# Altium schematic sheet Reset.SchDoc
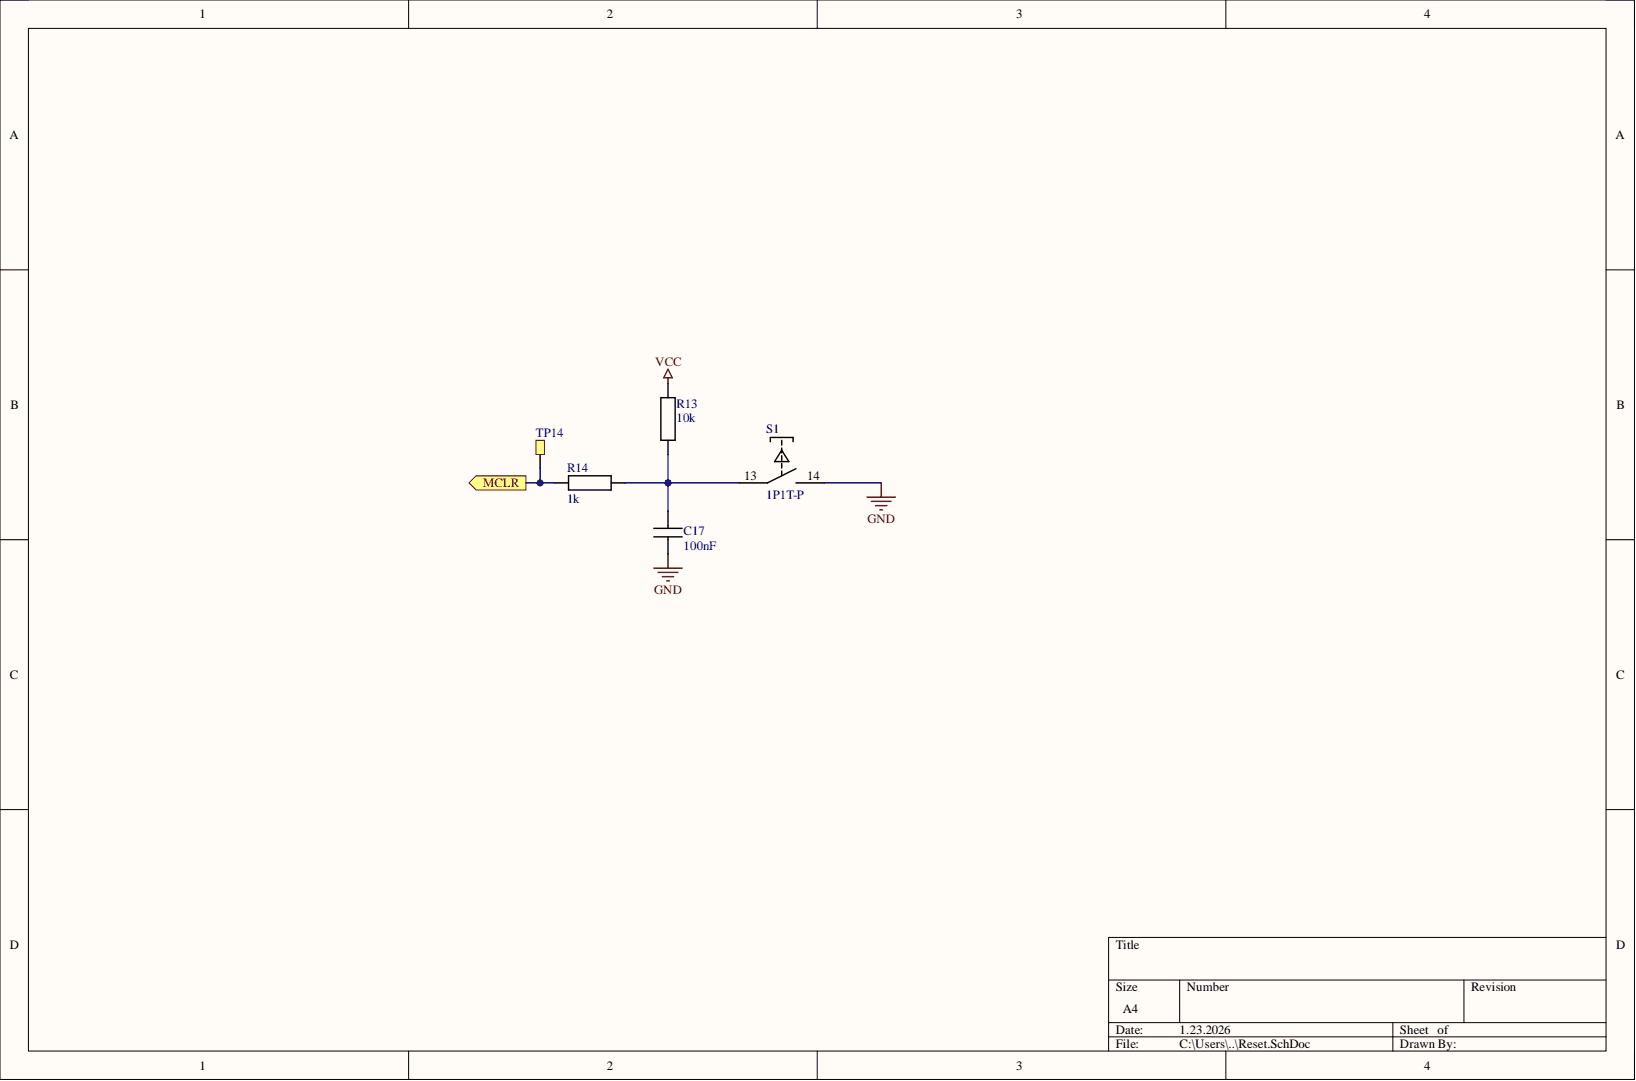
[diagram p0: Reset.SchDoc]

## Parts
C17  100nF  [CAPC1608X08M]
R13  10k  [RESC1608X06M]
R14  1k  [RESC1608X06M]
S1  1P1T-P  [TE_1825910-6]
TP14  5019  [TESTPOINT_SMD]  (value not drawn)

## Ports
MCLR

## Nets
GND: C17.1 S1.14
VCC: R13.2
MCLR: R14.1 TP14.1 ; port MCLR
NetC17_2: C17.2 R13.1 R14.2 S1.13

## Library data of the parts
C17 = CMP-065-000000-2: CAPC 100nF X7R 50V 10% 1608 (0603 INCH); Manufacturer: TDK; Manufacturer Number: CGA3E2X7R1H104K080AA; Current: NULL; IsStocked: 1; PN: PN-338083; TemperatureRange: -55°C to +125°C; Category: Passives; Component Kind: Standard; Dielectric: X7R; Library Name: ETML-ES; Power: NULL; SubCategory: Condensateurs ceramique; Supplier 1: ETML; Supplier Part Number 1: FAB.0603_C 100n; Supplier Price 1: 0.0100; TemperatureCoeff: NULL; Tolerance: ±10%; Value: 100nF; Voltage: 50V
R13 = CMP-066-000124-2: RES 10kohm  ±1% 0.1W  1608 (0603 INCH); Manufacturer: Yageo; Manufacturer Number: RC0603FR-0710KL; IsStocked: 1; PN: PN-896786; TemperatureCoeff: ±100ppm/°C; TemperatureRange: -55°C to 155°C; Voltage: 75V; Category: Passives; Component Kind: Standard; Library Name: ETML-ES; Power: 0.1W; SubCategory: Resistances couche epaisse; Supplier 1: ETML; Supplier Part Number 1: FAB.0603_R 10k; Supplier Price 1: 0.0200; Tolerance: ±1%; Value: 10k
R14 = CMP-066-000112-2: RES 1.0kohm  ±1% 0.1W  1608 (0603 INCH); Manufacturer: Yageo; Manufacturer Number: RC0603FR-071KL; IsStocked: 1; PN: PN-368287; TemperatureCoeff: ±100ppm/°C; TemperatureRange: -55°C to 155°C; Voltage: 75V; Category: Passives; Component Kind: Standard; Library Name: ETML-ES; Power: 0.1W; SubCategory: Resistances couche epaisse; Supplier 1: ETML; Supplier Part Number 1: FAB.0603_R 1k; Supplier Price 1: 0.0100; Tolerance: ±1%; Value: 1k
S1 = CMP-058-000005-2: SW 600X600X500 24V 50mA THT; Manufacturer: TE Connectivity; Manufacturer Number: 1825910-6; IsStocked: True; PN: PN-638027; TemperatureRange: -35°C to +85 °C; Category: Electromechanical; Component Kind: Standard; Current: 50mA; Library Name: ETML-ES; SubCategory: Commutateurs; Supplier 1: Distrelec AG; Supplier Part Number 1: 135-66-547; Supplier Price 1: 0.1642; Value: 1P1T-P; Voltage: 24V
TP14 = TESTPOINT: Test point smd; Component Kind: Standard; Supplier 1: Mouser; Supplier Part Number 1: 534-5019; Library Name: 2008_SablierElectronique_SCHLIB.SchLib
